# Altium schematic sheet IRIS-EXT-04-BRK_GTX-Breakout.SchDoc
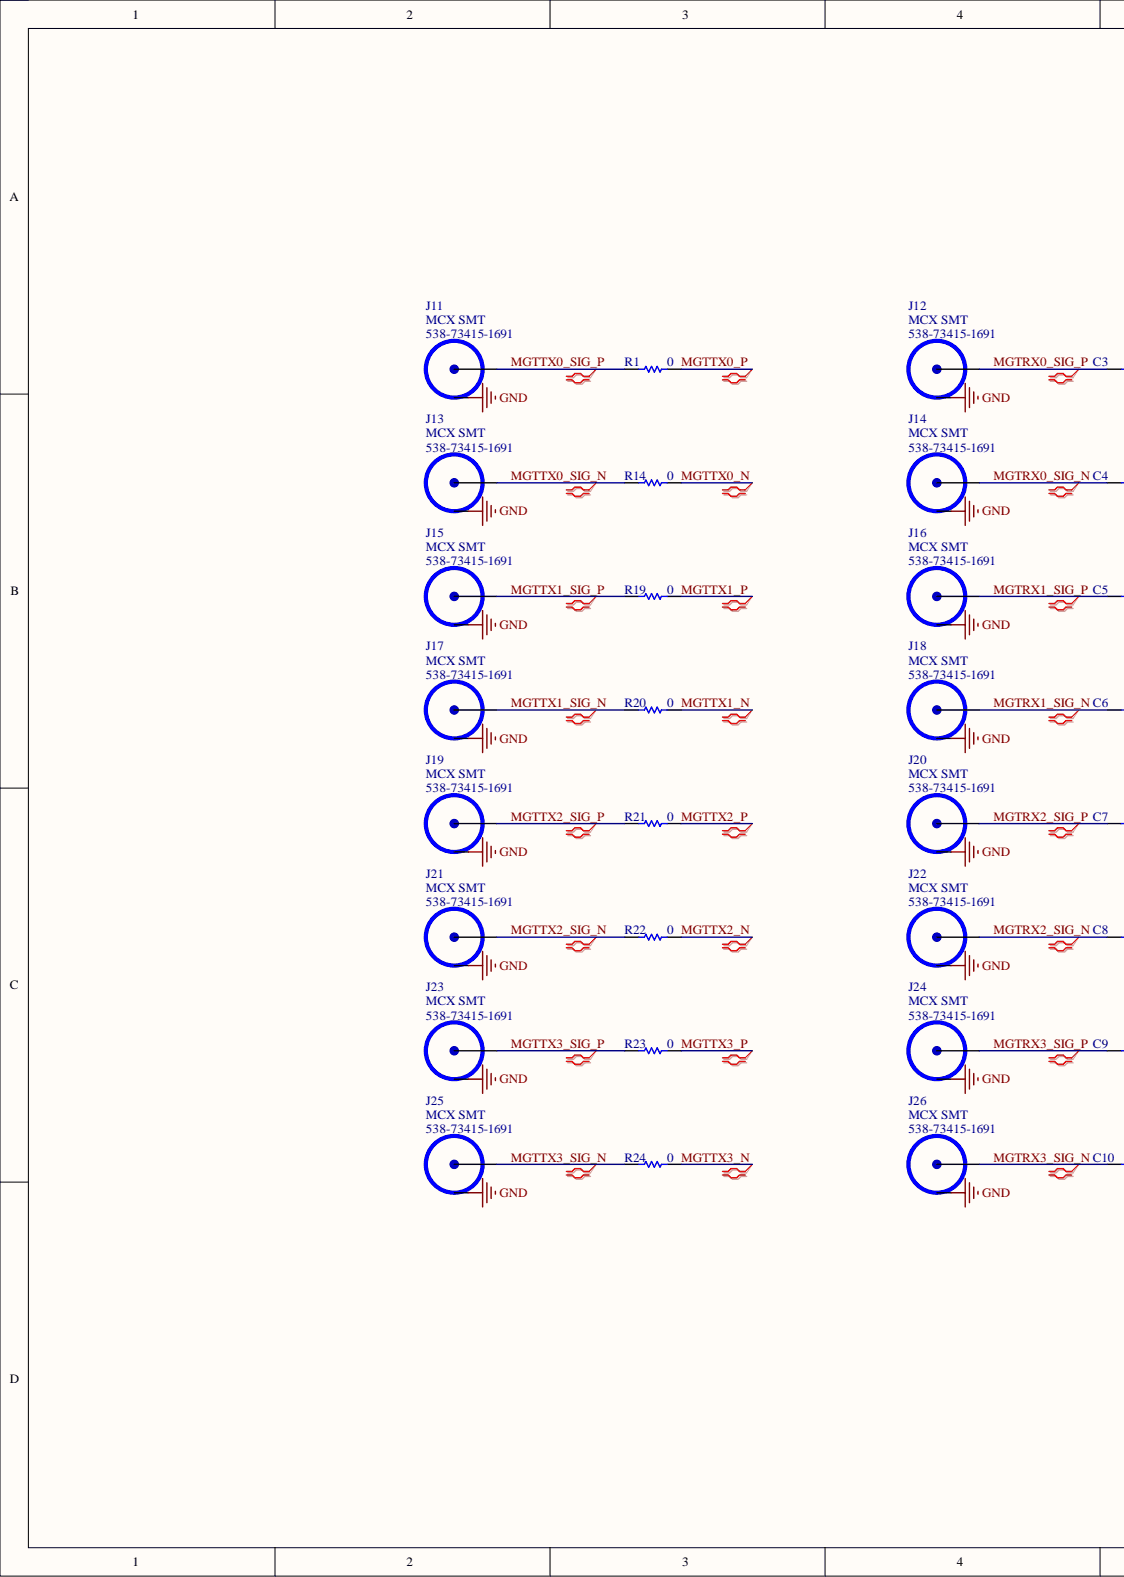
[diagram p0: IRIS-EXT-04-BRK_GTX-Breakout.SchDoc, left]
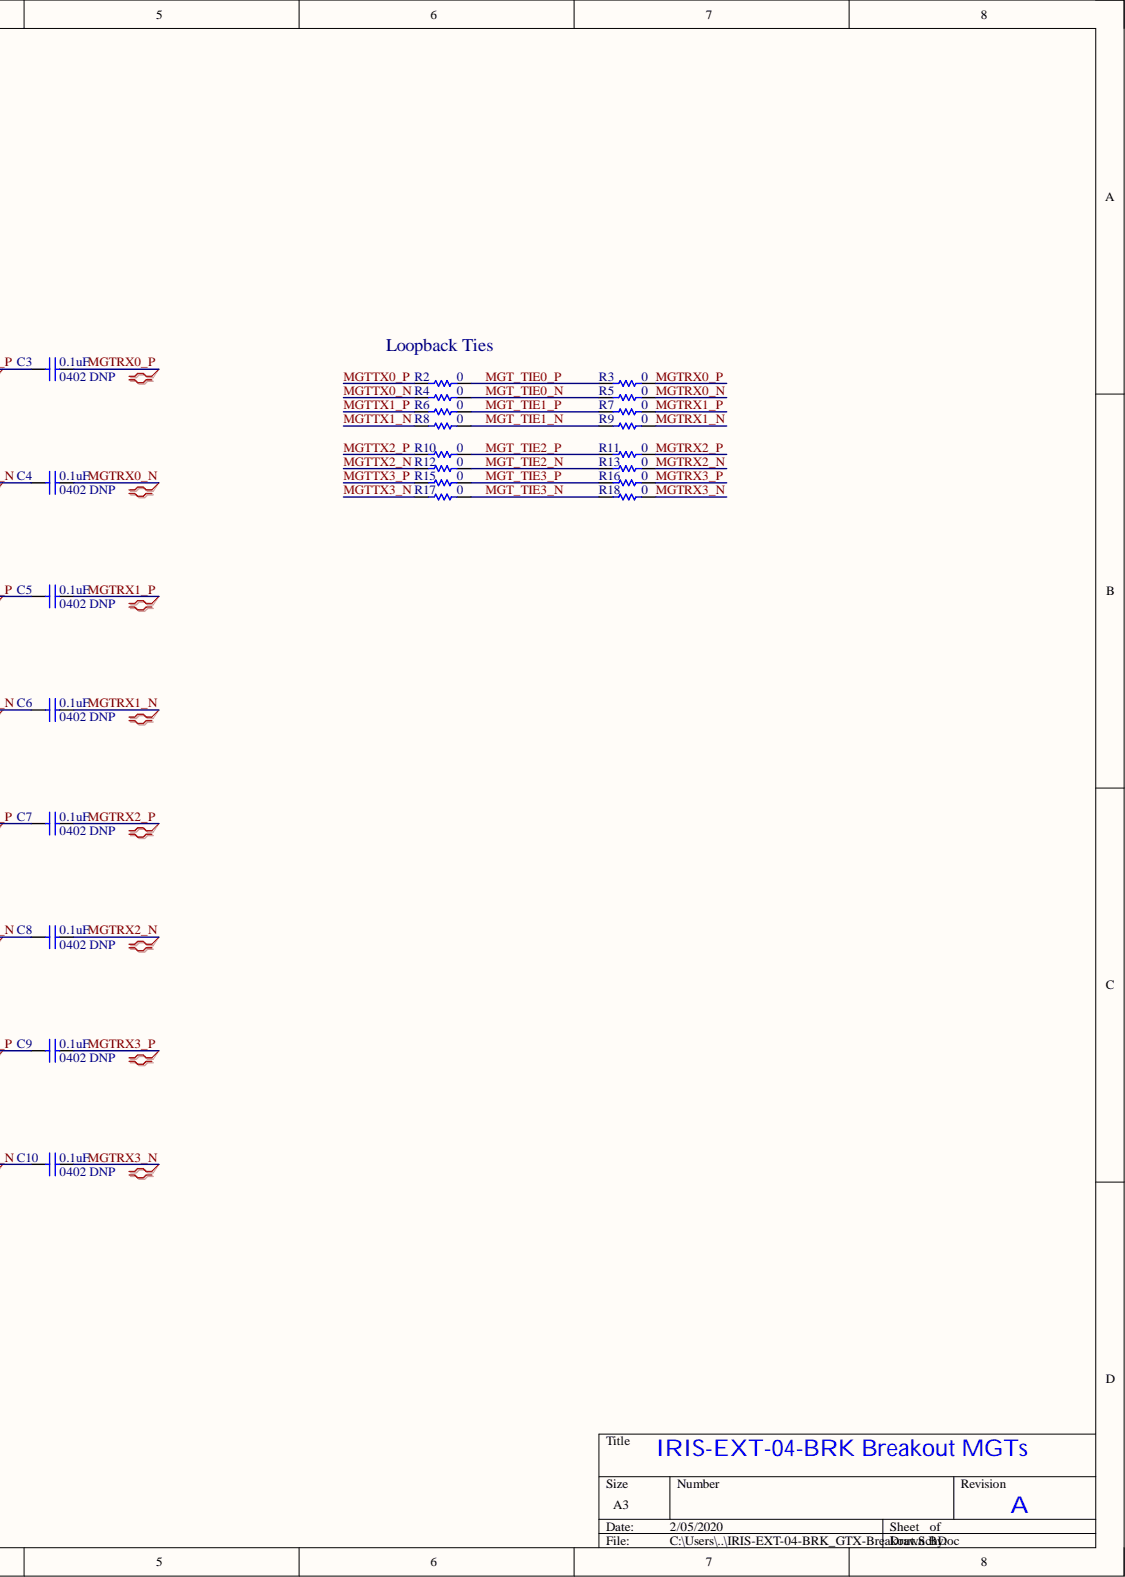
[diagram p1: IRIS-EXT-04-BRK_GTX-Breakout.SchDoc, right]

## Parts
C3  0402 DNP  value 0.1uF  [0402_SMT_CAP]
C4  0402 DNP  value 0.1uF  [0402_SMT_CAP]
C5  0402 DNP  value 0.1uF  [0402_SMT_CAP]
C6  0402 DNP  value 0.1uF  [0402_SMT_CAP]
C7  0402 DNP  value 0.1uF  [0402_SMT_CAP]
C8  0402 DNP  value 0.1uF  [0402_SMT_CAP]
C9  0402 DNP  value 0.1uF  [0402_SMT_CAP]
C10  0402 DNP  value 0.1uF  [0402_SMT_CAP]
J11  MCX SMT  Supplier Part Number 1: 538-73415-1691  [Molex-734151691]
J12  MCX SMT  Supplier Part Number 1: 538-73415-1691  [Molex-734151691]
J13  MCX SMT  Supplier Part Number 1: 538-73415-1691  [Molex-734151691]
J14  MCX SMT  Supplier Part Number 1: 538-73415-1691  [Molex-734151691]
J15  MCX SMT  Supplier Part Number 1: 538-73415-1691  [Molex-734151691]
J16  MCX SMT  Supplier Part Number 1: 538-73415-1691  [Molex-734151691]
J17  MCX SMT  Supplier Part Number 1: 538-73415-1691  [Molex-734151691]
J18  MCX SMT  Supplier Part Number 1: 538-73415-1691  [Molex-734151691]
J19  MCX SMT  Supplier Part Number 1: 538-73415-1691  [Molex-734151691]
J20  MCX SMT  Supplier Part Number 1: 538-73415-1691  [Molex-734151691]
J21  MCX SMT  Supplier Part Number 1: 538-73415-1691  [Molex-734151691]
J22  MCX SMT  Supplier Part Number 1: 538-73415-1691  [Molex-734151691]
J23  MCX SMT  Supplier Part Number 1: 538-73415-1691  [Molex-734151691]
J24  MCX SMT  Supplier Part Number 1: 538-73415-1691  [Molex-734151691]
J25  MCX SMT  Supplier Part Number 1: 538-73415-1691  [Molex-734151691]
J26  MCX SMT  Supplier Part Number 1: 538-73415-1691  [Molex-734151691]
R1  value 0  [0402_SMT_RES]  (hidden comment: 0402 DNP)
R2  value 0  [0603_SMT_RES]  (hidden comment: 0603)
R3  value 0  [0603_SMT_RES]  (hidden comment: 0603)
R4  value 0  [0603_SMT_RES]  (hidden comment: 0603)
R5  value 0  [0603_SMT_RES]  (hidden comment: 0603)
R6  value 0  [0603_SMT_RES]  (hidden comment: 0603)
R7  value 0  [0603_SMT_RES]  (hidden comment: 0603)
R8  value 0  [0603_SMT_RES]  (hidden comment: 0603)
R9  value 0  [0603_SMT_RES]  (hidden comment: 0603)
R10  value 0  [0603_SMT_RES]  (hidden comment: 0603)
R11  value 0  [0603_SMT_RES]  (hidden comment: 0603)
R12  value 0  [0603_SMT_RES]  (hidden comment: 0603)
R13  value 0  [0603_SMT_RES]  (hidden comment: 0603)
R14  value 0  [0402_SMT_RES]  (hidden comment: 0402 DNP)
R15  value 0  [0603_SMT_RES]  (hidden comment: 0603)
R16  value 0  [0603_SMT_RES]  (hidden comment: 0603)
R17  value 0  [0603_SMT_RES]  (hidden comment: 0603)
R18  value 0  [0603_SMT_RES]  (hidden comment: 0603)
R19  value 0  [0402_SMT_RES]  (hidden comment: 0402 DNP)
R20  value 0  [0402_SMT_RES]  (hidden comment: 0402 DNP)
R21  value 0  [0402_SMT_RES]  (hidden comment: 0402 DNP)
R22  value 0  [0402_SMT_RES]  (hidden comment: 0402 DNP)
R23  value 0  [0402_SMT_RES]  (hidden comment: 0402 DNP)
R24  value 0  [0402_SMT_RES]  (hidden comment: 0402 DNP)

## Nets
GND: J11.0 J12.0 J13.0 J14.0 J15.0 J16.0 J17.0 J18.0 J19.0 J20.0 J21.0 J22.0 J23.0 J24.0 J25.0 J26.0
MGT_TIE0_N: R4.1 R5.2
MGT_TIE0_P: R2.1 R3.2
MGT_TIE1_N: R8.1 R9.2
MGT_TIE1_P: R6.1 R7.2
MGT_TIE2_N: R12.1 R13.2
MGT_TIE2_P: R10.1 R11.2
MGT_TIE3_N: R17.1 R18.2
MGT_TIE3_P: R15.1 R16.2
MGTRX0_N: C4.1 R5.1
MGTRX0_P: C3.1 R3.1
MGTRX0_SIG_N: C4.2 J14.1
MGTRX0_SIG_P: C3.2 J12.1
MGTRX1_N: C6.1 R9.1
MGTRX1_P: C5.1 R7.1
MGTRX1_SIG_N: C6.2 J18.1
MGTRX1_SIG_P: C5.2 J16.1
MGTRX2_N: C8.1 R13.1
MGTRX2_P: C7.1 R11.1
MGTRX2_SIG_N: C8.2 J22.1
MGTRX2_SIG_P: C7.2 J20.1
MGTRX3_N: C10.1 R18.1
MGTRX3_P: C9.1 R16.1
MGTRX3_SIG_N: C10.2 J26.1
MGTRX3_SIG_P: C9.2 J24.1
MGTTX0_N: R4.2 R14.2
MGTTX0_P: R1.2 R2.2
MGTTX0_SIG_N: J13.1 R14.1
MGTTX0_SIG_P: J11.1 R1.1
MGTTX1_N: R8.2 R20.2
MGTTX1_P: R6.2 R19.2
MGTTX1_SIG_N: J17.1 R20.1
MGTTX1_SIG_P: J15.1 R19.1
MGTTX2_N: R12.2 R22.2
MGTTX2_P: R10.2 R21.2
MGTTX2_SIG_N: J21.1 R22.1
MGTTX2_SIG_P: J19.1 R21.1
MGTTX3_N: R17.2 R24.2
MGTTX3_P: R15.2 R23.2
MGTTX3_SIG_N: J25.1 R24.1
MGTTX3_SIG_P: J23.1 R23.1

## Library data of the parts
C3-C10 = Cap_0402: 0402 SMT Capacitor; Component Kind: Standard; Supplier 1: Mouser; Supplier Part Number 1: 81-GRM155R71C104JA8D; Library Name: RNG_Parts.SchLib
J11-J26 = Molex-734151691: Molex 50 Ohm SMT MCX Jack; Component Kind: Standard; Supplier 1: Mouser; Library Name: RNG_Parts.SchLib
R1 R14 R19-R24 = Res_0402: 0402 SMT Resistor; Component Kind: Standard; Supplier 1: Mouser; Supplier Part Number 1: 667-ERJ-2GE0R00X; Library Name: RNG_Parts.SchLib
R2-R13 R15-R18 = Res_0603: 0603 SMT Resistor; ClassName: NoCollide; Component Kind: Standard; Supplier 1: Mouser; Supplier 2: Digi-Key; Supplier Part Number 1: 667-ERJ-3GEY0R00V; Supplier Part Number 2: P0.0GCT-ND; Library Name: Skylark_Master.SchLib
